# Altium schematic sheet [15] - DDR3 MEMORY.SchDoc("[15] - DDR3 MEMORY")
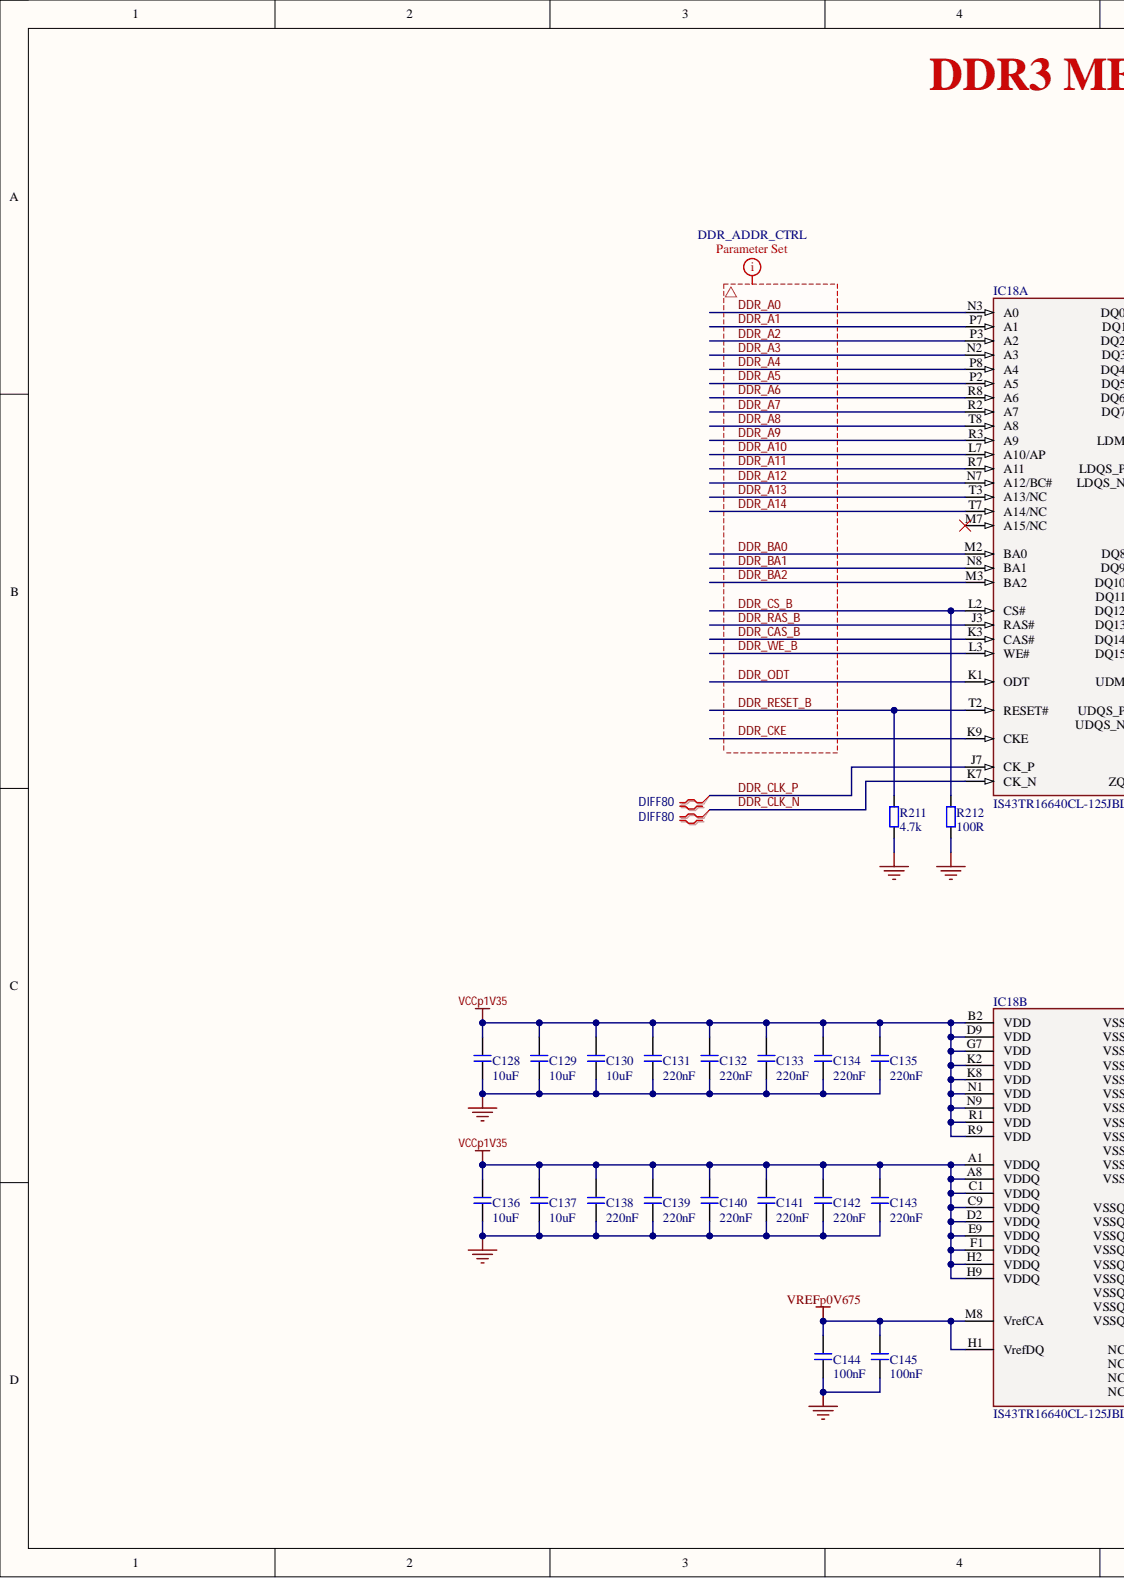
[diagram p0: [15] - DDR3 MEMORY.SchDoc, left]
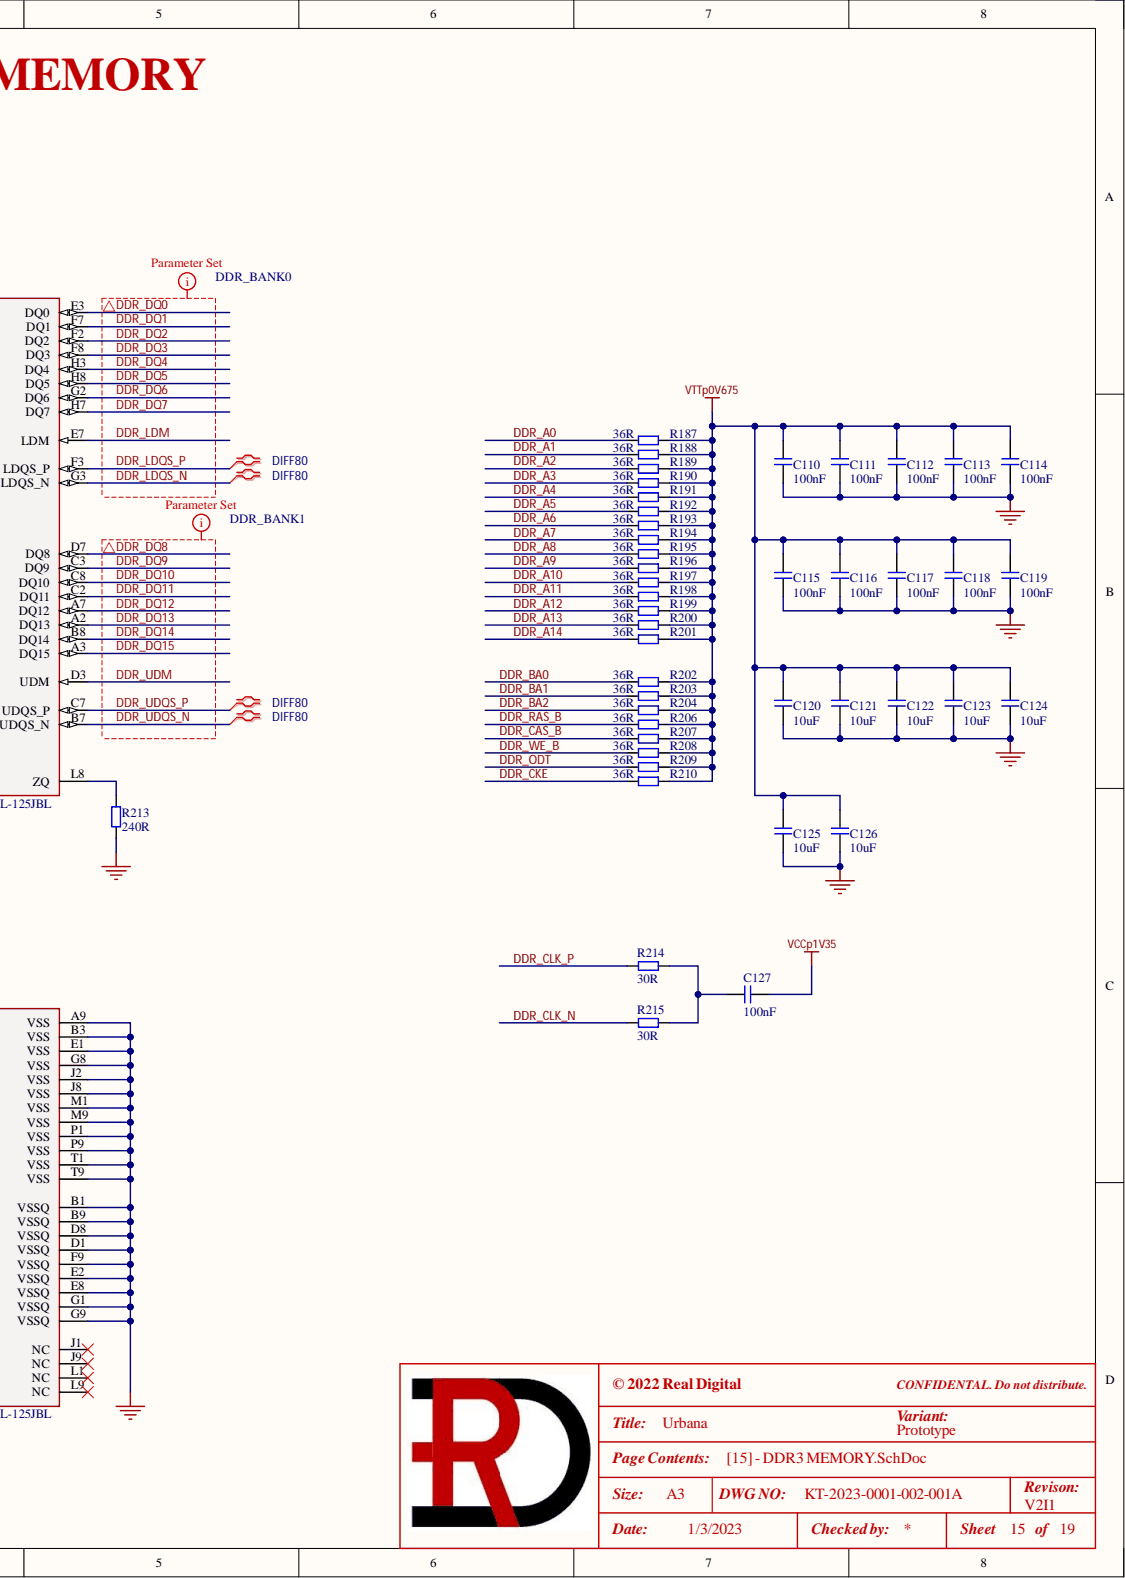
[diagram p1: [15] - DDR3 MEMORY.SchDoc, right]

## Parts
C110  100nF  [C0402]
C111  100nF  [C0402]
C112  100nF  [C0402]
C113  100nF  [C0402]
C114  100nF  [C0402]
C115  100nF  [C0402]
C116  100nF  [C0402]
C117  100nF  [C0402]
C118  100nF  [C0402]
C119  100nF  [C0402]
C120  10uF  [C0402]
C121  10uF  [C0402]
C122  10uF  [C0402]
C123  10uF  [C0402]
C124  10uF  [C0402]
C125  10uF  [C0402]
C126  10uF  [C0402]
C127  100nF  [C0402]
C128  10uF  [C0402]
C129  10uF  [C0402]
C130  10uF  [C0402]
C131  220nF  [C0402]
C132  220nF  [C0402]
C133  220nF  [C0402]
C134  220nF  [C0402]
C135  220nF  [C0402]
C136  10uF  [C0402]
C137  10uF  [C0402]
C138  220nF  [C0402]
C139  220nF  [C0402]
C140  220nF  [C0402]
C141  220nF  [C0402]
C142  220nF  [C0402]
C143  220nF  [C0402]
C144  100nF  [C0402]
C145  100nF  [C0402]
IC18  IS43TR16640CL-125JBL  [FBGA96]  (parts A,B)
R187  36R  [R0402]
R188  36R  [R0402]
R189  36R  [R0402]
R190  36R  [R0402]
R191  36R  [R0402]
R192  36R  [R0402]
R193  36R  [R0402]
R194  36R  [R0402]
R195  36R  [R0402]
R196  36R  [R0402]
R197  36R  [R0402]
R198  36R  [R0402]
R199  36R  [R0402]
R200  36R  [R0402]
R201  36R  [R0402]
R202  36R  [R0402]
R203  36R  [R0402]
R204  36R  [R0402]
R206  36R  [R0402]
R207  36R  [R0402]
R208  36R  [R0402]
R209  36R  [R0402]
R210  36R  [R0402]
R211  4.7k  [R0402]
R212  100R  [R0402]
R213  240R  [R0402]
R214  30R  [R0402]
R215  30R  [R0402]

## Nets
GND: C110.1 C111.1 C112.1 C113.1 C114.1 C115.1 C116.1 C117.1 C118.1 C119.1 C120.1 C121.1 C122.1 C123.1 C124.1 C125.1 C126.1 C128.1 C129.1 C130.1 C131.1 C132.1 C133.1 C134.1 C135.1 C136.1 C137.1 C138.1 C139.1 C140.1 C141.1 C142.1 C143.1 C144.1 C145.1 IC18.A9(VSS) IC18.B1(VSSQ) IC18.B3(VSS) IC18.B9(VSSQ) IC18.D1(VSSQ) IC18.D8(VSSQ) IC18.E1(VSS) IC18.E2(VSSQ) IC18.E8(VSSQ) IC18.F9(VSSQ) IC18.G1(VSSQ) IC18.G8(VSS) IC18.G9(VSSQ) IC18.J2(VSS) IC18.J8(VSS) IC18.M1(VSS) IC18.M9(VSS) IC18.P1(VSS) IC18.P9(VSS) IC18.T1(VSS) IC18.T9(VSS) R211.1 R212.1 R213.1
VCCp1V35: C127.1 C128.2 C129.2 C130.2 C131.2 C132.2 C133.2 C134.2 C135.2 C136.2 C137.2 C138.2 C139.2 C140.2 C141.2 C142.2 C143.2 IC18.A1(VDDQ) IC18.A8(VDDQ) IC18.B2(VDD) IC18.C1(VDDQ) IC18.C9(VDDQ) IC18.D2(VDDQ) IC18.D9(VDD) IC18.E9(VDDQ) IC18.F1(VDDQ) IC18.G7(VDD) IC18.H2(VDDQ) IC18.H9(VDDQ) IC18.K2(VDD) IC18.K8(VDD) IC18.N1(VDD) IC18.N9(VDD) IC18.R1(VDD) IC18.R9(VDD)
VREFp0V675: C144.2 C145.2 IC18.H1(VrefDQ) IC18.M8(VrefCA)
VTTp0V675: C110.2 C111.2 C112.2 C113.2 C114.2 C115.2 C116.2 C117.2 C118.2 C119.2 C120.2 C121.2 C122.2 C123.2 C124.2 C125.2 C126.2 R187.2 R188.2 R189.2 R190.2 R191.2 R192.2 R193.2 R194.2 R195.2 R196.2 R197.2 R198.2 R199.2 R200.2 R201.2 R202.2 R203.2 R204.2 R206.2 R207.2 R208.2 R209.2 R210.2
DDR_A0: IC18.N3(A0) R187.1
DDR_A1: IC18.P7(A1) R188.1
DDR_A2: IC18.P3(A2) R189.1
DDR_A3: IC18.N2(A3) R190.1
DDR_A4: IC18.P8(A4) R191.1
DDR_A5: IC18.P2(A5) R192.1
DDR_A6: IC18.R8(A6) R193.1
DDR_A7: IC18.R2(A7) R194.1
DDR_A8: IC18.T8(A8) R195.1
DDR_A9: IC18.R3(A9) R196.1
DDR_A10: IC18.L7(A10/AP) R197.1
DDR_A11: IC18.R7(A11) R198.1
DDR_A12: IC18.N7(A12/BC#) R199.1
DDR_A13: IC18.T3(A13/NC) R200.1
DDR_A14: IC18.T7(A14/NC) R201.1
DDR_BA0: IC18.M2(BA0) R202.1
DDR_BA1: IC18.N8(BA1) R203.1
DDR_BA2: IC18.M3(BA2) R204.1
DDR_CAS_B: IC18.K3(CAS#) R207.1
DDR_CKE: IC18.K9(CKE) R210.1
DDR_CLK_N: IC18.K7(CK_N) R215.1
DDR_CLK_P: IC18.J7(CK_P) R214.1
DDR_CS_B: IC18.L2(CS#) R212.2
DDR_DQ0: IC18.E3(DQ0)
DDR_DQ1: IC18.F7(DQ1)
DDR_DQ2: IC18.F2(DQ2)
DDR_DQ3: IC18.F8(DQ3)
DDR_DQ4: IC18.H3(DQ4)
DDR_DQ5: IC18.H8(DQ5)
DDR_DQ6: IC18.G2(DQ6)
DDR_DQ7: IC18.H7(DQ7)
DDR_DQ8: IC18.D7(DQ8)
DDR_DQ9: IC18.C3(DQ9)
DDR_DQ10: IC18.C8(DQ10)
DDR_DQ11: IC18.C2(DQ11)
DDR_DQ12: IC18.A7(DQ12)
DDR_DQ13: IC18.A2(DQ13)
DDR_DQ14: IC18.B8(DQ14)
DDR_DQ15: IC18.A3(DQ15)
DDR_LDM: IC18.E7(LDM)
DDR_LDQS_N: IC18.G3(LDQS_N)
DDR_LDQS_P: IC18.F3(LDQS_P)
DDR_ODT: IC18.K1(ODT) R209.1
DDR_RAS_B: IC18.J3(RAS#) R206.1
DDR_RESET_B: IC18.T2(RESET#) R211.2
DDR_UDM: IC18.D3(UDM)
DDR_UDQS_N: IC18.B7(UDQS_N)
DDR_UDQS_P: IC18.C7(UDQS_P)
DDR_WE_B: IC18.L3(WE#) R208.1
NetC127_2: C127.2 R214.2 R215.2
NetIC18_L8: IC18.L8(ZQ) R213.2

Not connected: IC18 J1(NC) J9(NC) L1(NC) L9(NC) M7(A15/NC)

## Library data of the parts
C110-C119 C127 C144 C145 = GRM155R71E104KE14J: CAP CER 0.1uF 25V X7R 0402; Manufacturer 1: Murata Electronics; .Checked_PCB: AC; .Creator: RV; C P/N: RD-111-0006; LoadStatus: Load; Tolerance: 10%; Category: Capacitors; Component Kind: Standard; Library Name: KT-RealDigital.IntLib; Manufacturer 1 Part Number: GRM155R71E104KE14J; Temperature Coefficient: X7R; Voltage - Rated: 25V; .Checked_SCH: AC
C120-C126 C128-C130 C136 C137 = GRM155R60J106ME47D: CAP CER 10uF 6.3V X5R 0402; Manufacturer 1: Murata Electronics; .Checked_PCB: RV; LoadStatus: Load; Tolerance: 20%; Category: Capacitors; Component Kind: Standard; Library Name: KT-RealDigital.IntLib; Manufacturer 1 Part Number: GRM155R60J106ME47D; Temperature Coefficient: X5R; Voltage - Rated: 6.3V; .Checked_SCH: RV; .Creator: RV
C131-C135 C138-C143 = GRM155R71C224KA12D: CAP CER 0.22uF 16V X7R 0402; Manufacturer 1: Murata Electronics; .Checked_PCB: AC; .Creator: RV; LoadStatus: Load; Tolerance: 10%; Category: Capacitors; Component Kind: Standard; Library Name: KT-RealDigital.IntLib; Manufacturer 1 Part Number: GRM155R71C224KA12D; Temperature Coefficient: X7R; Voltage - Rated: 16V; .Checked_SCH: AC
IC18 = IS43TR16640CL-125JBL: 1G, 1.35V, DDR3, 64MX16, 1600MT; Manufacturer 1: ISSI; .Checked_PCB: RV; LoadStatus: Load; Category: IC; Component Kind: Standard; Library Name: KT-RealDigital.IntLib; Manufacturer 1 Part Number: IS43TR16640CL-125JBL; .Checked_SCH: RV; .Creator: RV
R187-R204 R206-R210 = RC0402FR-0736RL: RES SMD 36 OHM 1% 1/16W 0402; Manufacturer 1: Yageo; .Checked_PCB: RV; LoadStatus: Load; Category: Resistors; Component Kind: Standard; Library Name: KT-RealDigital.IntLib; Manufacturer 1 Part Number: RC0402FR-0736RL; Tolerance: 1%; .Checked_SCH: RV; .Creator: RV
R211 = RC0402FR-074K7P: RES SMD 4.7K OHM 1% 1/16W 0402; Manufacturer 1: Yageo; .Checked_PCB: AC; .Creator: RV; C P/N: 101-0129; LoadStatus: Load; Category: Resistors; Component Kind: Standard; Library Name: KT-RealDigital.IntLib; Manufacturer 1 Part Number: RC0402FR-074K7P; Tolerance: 1%; .Checked_SCH: AC
R212 = RC0402JR-07100RL: RES SMD 100 OHM 5% 1/16W 0402; Manufacturer 1: Yageo; .Checked_PCB: AC; .Creator: RV; C P/N: 101-0035; LoadStatus: Load; Category: Resistors; Component Kind: Standard; Library Name: KT-RealDigital.IntLib; Manufacturer 1 Part Number: RC0402JR-07100RL; Tolerance: 5%; .Checked_SCH: AC
R213 = RC0402FR-07240RL: RES SMD 240 OHM 1% 1/16W 0402; Manufacturer 1: Yageo; .Checked_PCB: AC; .Creator: RV; LoadStatus: Load; Category: Resistors; Component Kind: Standard; Library Name: KT-RealDigital.IntLib; Manufacturer 1 Part Number: RC0402FR-07240RL; Tolerance: 1%; .Checked_SCH: AC
R214 R215 = RC0402FR-0730RL: RES SMD 30 OHM 1% 1/16W 0402; Manufacturer 1: Yageo; .Checked_PCB: RV; LoadStatus: Load; Category: Resistors; Component Kind: Standard; Library Name: KT-RealDigital.IntLib; Manufacturer 1 Part Number: RC0402FR-0730RL; Tolerance: 1%; .Checked_SCH: RV; .Creator: RV
